# Altium schematic sheet OTL2_LED-Driver.SchDoc(OTL2_LED-Driver) (continued)
## Library data of the parts (continued)
R6 = RC0402FR-0722K1L: SMD Chip Resistor, 22.1 kOhm, ï¿½ 1%, 62.5 mW, 0402 [1005 Metric], Thick Film, General Purpose; Manufacturer: Yageo; Max Power Dissipation: 63mW; Power Rating: 62.5mW; Resistance: 22.1kR; Temperature Coefficient: 100ppm/°C; Voltage Rating: 50V; Case Code (Imperial): 0402; Case Code (Metric): 1005; Case/Package: 0402; Category: Chip SMD Resistors; Component Kind: Standard; ComponentLink1Description: https://datasheet.ciiva.com/2553/pyu-r-int-thick-7-2553200.pdf?src-supplier=Digikey; ComponentLink1URL: https://datasheet.ciiva.com/2553/pyu-r-int-thick-7-2553200.pdf?src-supplier=Digikey; ComponentLink2Description: https://datasheet.ciiva.com/2553/pyu-r-int-thick-7-2553201.pdf?src-supplier=Digikey; ComponentLink2URL: https://datasheet.ciiva.com/2553/pyu-r-int-thick-7-2553201.pdf?src-supplier=Digikey; ComponentLink3Description: https://datasheet.ciiva.com/2553/pyu-r-int-thick-7-2553202.pdf?src-supplier=Digikey; ComponentLink3URL: https://datasheet.ciiva.com/2553/pyu-r-int-thick-7-2553202.pdf?src-supplier=Digikey; ComponentLink4Description: https://datasheet.ciiva.com/7983/1018594-7983501.pdf?src-supplier=Element14; ComponentLink4URL: https://datasheet.ciiva.com/7983/1018594-7983501.pdf?src-supplier=Element14; ComponentLink5Description: https://datasheet.ciiva.com/15189/getdatasheetpartid-251817-15189388.pdf?src-supplier=Verical; ComponentLink5URL: https://datasheet.ciiva.com/15189/getdatasheetpartid-251817-15189388.pdf?src-supplier=Verical; ComponentLink6Description: LCSC; ComponentLink6URL: https://www.lcsc.com/product-detail/Chip-Resistor-Surface-Mount_YAGEO-RC0402FR-0722K1L_C163478.html; Construction: Rectangular; Depth: 0.5mm; Features: Moisture Resistant; Height: 0.016inch; Lead Free: Lead Free; Length: 0.039inch; Manufacturer Part Number: RC0402FR-0722K1L; Max Operating Temperature: 155°C; Military Standard: Not; Min Operating Temperature: -55°C; Number of Terminations: 2; Package Quantity: 1; Packaging: Tape and Reel; Pricing 1: 10000=0.0007, 50000=0.0007, 200000=0.0006, 500000=0.0006, 1250000=0.0006 (USD); Reach SVHC Compliant: No; Resistance Tolerance: 1%; Resistor Type: Thick Film; RoHS: Yes; RoHS Compliant: Yes; Series: RC; Stock 1: 30000; Supplier: LCSC; Supplier 1: Future Electronics; Supplier Part Number: C163478; Supplier Part Number 1: 7052236; Technology: THICK FILM; Termination: SMD/SMT; Tolerance: 1%; Voltage Rating (DC): 50V; Width: 0.02inch; Working Voltage: 50V; Library Name: josh-lib.SchLib
R7 R8 R35 = R0402 4K7 1% 63mW: Res Thick Film 0402 4.7K Ohm 1% 0.063W(1/16W) ±100ppm/C Pad SMD T/R; Manufacturer: Royal Ohm; Manufacturer Part Number: 0402WGF4701TCE; Resistance: 4.7kΩ; Temperature Coefficient: 100ppm/°C; Category: Chip SMD Resistors; Component Kind: Standard; ComponentLink1Description: https://datasheet.octopart.com:443/0402WGF4701TCE-Royal-Ohm-datasheet-165785611.pdf?src-supplier=TME; ComponentLink1URL: https://datasheet.octopart.com:443/0402WGF4701TCE-Royal-Ohm-datasheet-165785611.pdf?src-supplier=TME; ComponentLink2Description: https://datasheet.octopart.com:443/0402WGF4701TCE-Royal-Ohm-datasheet-157855877.pdf?src-supplier=TME; ComponentLink2URL: https://datasheet.octopart.com:443/0402WGF4701TCE-Royal-Ohm-datasheet-157855877.pdf?src-supplier=TME; ComponentLink3Description: https://datasheet.octopart.com:443/0402WGF4701TCE-Royal-Ohm-datasheet-62069544.pdf?src-supplier=TME; ComponentLink3URL: https://datasheet.octopart.com:443/0402WGF4701TCE-Royal-Ohm-datasheet-62069544.pdf?src-supplier=TME; ComponentLink4Description: https://datasheet.octopart.com:443/0402WGF4701TCE-Royal-Ohm-datasheet-17591902.pdf?src-supplier=TME; ComponentLink4URL: https://datasheet.octopart.com:443/0402WGF4701TCE-Royal-Ohm-datasheet-17591902.pdf?src-supplier=TME; ComponentLink5Description: LCSC; ComponentLink5URL: https://jlcpcb.com/partdetail/26643-0402WGF4701TCE/C25900; Pricing 1: 10000=0.004 (USD); RoHS: Non-Compliant; Supplier: LCSC; Supplier 1: Mouser; Supplier Part Number: C25900; Supplier Part Number 1: 303-0402WGF4701TCE; Library Name: josh-lib.SchLib
R9 R10 R17 R33 R36 = 0402WGF1002TCE: Res Thick Film 0402 10K Ohm 1% 0.063W(1/16W) ±100ppm/C Pad SMD T/R; Manufacturer: Uni-Royal; Resistance: 10kΩ; Temperature Coefficient: 100ppm/°C; Category: Chip SMD Resistors; Component Kind: Standard; ComponentLink1Description: https://datasheet.octopart.com:443/0402WGF1002TCE-Royal-Ohm-datasheet-165785611.pdf?src-supplier=TME; ComponentLink1URL: https://datasheet.octopart.com:443/0402WGF1002TCE-Royal-Ohm-datasheet-165785611.pdf?src-supplier=TME; ComponentLink2Description: https://datasheet.octopart.com:443/0402WGF1002TCE-Royal-Ohm-datasheet-157855877.pdf?src-supplier=TME; ComponentLink2URL: https://datasheet.octopart.com:443/0402WGF1002TCE-Royal-Ohm-datasheet-157855877.pdf?src-supplier=TME; ComponentLink3Description: https://datasheet.octopart.com:443/0402WGF1002TCE-Royal-Ohm-datasheet-62069544.pdf?src-supplier=TME; ComponentLink3URL: https://datasheet.octopart.com:443/0402WGF1002TCE-Royal-Ohm-datasheet-62069544.pdf?src-supplier=TME; ComponentLink4Description: https://datasheet.octopart.com:443/0402WGF1002TCE-Royal-Ohm-datasheet-17591902.pdf?src-supplier=TME; ComponentLink4URL: https://datasheet.octopart.com:443/0402WGF1002TCE-Royal-Ohm-datasheet-17591902.pdf?src-supplier=TME; ComponentLink5Description: LCSC; ComponentLink5URL: https://jlcpcb.com/partdetail/26487-0402WGF1002TCE/C25744; Manufacturer Part Number: 0402WGF1002TCE; Pricing 1: 10000=0.001 (USD); RoHS: Non-Compliant; Stock 1: 130000; Supplier: LCSC; Supplier 1: Mouser; Supplier Part Number: C25744; Supplier Part Number 1: 303-0402WGF1002TCE; Library Name: josh-lib.SchLib
R11 R12 R30 R38 R39 = RC0402FR-070RL: Res Thick Film 0402 0 Ohm Molded SMD SMD Paper T/R; Manufacturer: Yageo; Power Rating: 62.5mW; Resistance: 0mR; Temperature Coefficient: 200ppm/°C; Tolerance: 1%; Voltage Rating: 50V; Case Code (Imperial): 0402; Case Code (Metric): 1005; Case/Package: 0402; Category: Chip SMD Resistors; Component Kind: Standard; ComponentLink1Description: https://datasheet.ciiva.com/8896/pyu-rc0402-51-rohs-l-6-8896149.pdf?src-supplier=Avnet; ComponentLink1URL: https://datasheet.ciiva.com/8896/pyu-rc0402-51-rohs-l-6-8896149.pdf?src-supplier=Avnet; ComponentLink2Description: https://datasheet.ciiva.com/16790/pyu-rc0402-51-rohs-l-6-r-16790444.pdf?src-supplier=Future+Electronics; ComponentLink2URL: https://datasheet.ciiva.com/16790/pyu-rc0402-51-rohs-l-6-r-16790444.pdf?src-supplier=Future+Electronics; ComponentLink3Description: https://datasheet.ciiva.com/28419/pyu-rc0402-51-rohs-l-6-28419661.pdf?src-supplier=Avnet+Europe; ComponentLink3URL: https://datasheet.ciiva.com/28419/pyu-rc0402-51-rohs-l-6-28419661.pdf?src-supplier=Avnet+Europe; ComponentLink4Description: https://datasheet.ciiva.com/16869/pyu-rc0402-51-rohs-l-6-16869518.pdf?src-supplier=Avnet+Europe; ComponentLink4URL: https://datasheet.ciiva.com/16869/pyu-rc0402-51-rohs-l-6-16869518.pdf?src-supplier=Avnet+Europe; ComponentLink5Description: LCSC; ComponentLink5URL: https://www.lcsc.com/product-detail/Chip-Resistor-Surface-Mount_YAGEO-RC0402FR-070RL_C106231.html; Composition: Thick Film; Contact Plating: Tin,Matte; Height: 0.35mm; Lead Free: Lead Free; Length: 1mm; Manufacturer Part Number: RC0402FR-070RL; Max Operating Temperature: 155°C; Min Operating Temperature: -55°C; Mount: Surface Mount; Packaging: Tape and Reel; Pricing 1: 1=0.10000, 10=0.01300, 25=0.00920, 50=0.00720, 100=0.00530, 250=0.00400, 500=0.00320, 1000=0.00236, 5000=0.00169 (USD); Radiation Hardening: No; RoHS: Yes; Stock 1: 1551811; Supplier: LCSC; Supplier 1: Digi-Key; Supplier Part Number: C106231; Supplier Part Number 1: 311-0.0LRCT-ND; Termination: SMD/SMT; Width: 0.5mm; Library Name: josh-lib.SchLib
R13 R14 = R0402 5K1 1% 63mW: Res Thick Film 0402 5.1K Ohm 1% 0.063W(1/16W) ±100ppm/C Pad SMD T/R; Manufacturer: Uni-Royal; Manufacturer Part Number: 0402WGF5101TCE; Resistance: 5.1kΩ; Temperature Coefficient: 100ppm/°C; Category: Chip SMD Resistors; Component Kind: Standard; ComponentLink1Description: https://datasheet.octopart.com:443/0402WGF5101TCE-Royal-Ohm-datasheet-165785611.pdf?src-supplier=TME; ComponentLink1URL: https://datasheet.octopart.com:443/0402WGF5101TCE-Royal-Ohm-datasheet-165785611.pdf?src-supplier=TME; ComponentLink2Description: https://datasheet.octopart.com:443/0402WGF5101TCE-Royal-Ohm-datasheet-157855877.pdf?src-supplier=TME; ComponentLink2URL: https://datasheet.octopart.com:443/0402WGF5101TCE-Royal-Ohm-datasheet-157855877.pdf?src-supplier=TME; ComponentLink3Description: https://datasheet.octopart.com:443/0402WGF5101TCE-Royal-Ohm-datasheet-62069544.pdf?src-supplier=TME; ComponentLink3URL: https://datasheet.octopart.com:443/0402WGF5101TCE-Royal-Ohm-datasheet-62069544.pdf?src-supplier=TME; ComponentLink4Description: https://datasheet.octopart.com:443/0402WGF5101TCE-Royal-Ohm-datasheet-17591902.pdf?src-supplier=TME; ComponentLink4URL: https://datasheet.octopart.com:443/0402WGF5101TCE-Royal-Ohm-datasheet-17591902.pdf?src-supplier=TME; ComponentLink5Description: https://datasheet.octopart.com:443/0402WGF5101TCE-Royal-Ohm-datasheet-181080982.pdf?src-supplier=Rapid+Electronics; ComponentLink5URL: https://datasheet.octopart.com:443/0402WGF5101TCE-Royal-Ohm-datasheet-181080982.pdf?src-supplier=Rapid+Electronics; ComponentLink6Description: LCSC; ComponentLink6URL: https://jlcpcb.com/partdetail/26648-0402WGF5101TCE/C25905; Pricing 1: 10000=0.004 (USD); Stock 1: 40000; Supplier: LCSC; Supplier 1: Mouser; Supplier Part Number: C25905; Supplier Part Number 1: 303-0402WGF5101TCE; Library Name: josh-lib.SchLib
R15 = R0402 1M 1% 63mW: Res Thick Film 0402 1M Ohm 1% 0.063W(1/16W) ±100ppm/C Pad SMD T/R; Manufacturer: Uni-Royal; Manufacturer Part Number: 0402WGF1004TCE; Resistance: 1MΩ; Temperature Coefficient: 100ppm/°C; Category: Chip SMD Resistors; Component Kind: Standard; ComponentLink1Description: https://datasheet.octopart.com:443/0402WGF1004TCE-Royal-Ohm-datasheet-165785611.pdf?src-supplier=TME; ComponentLink1URL: https://datasheet.octopart.com:443/0402WGF1004TCE-Royal-Ohm-datasheet-165785611.pdf?src-supplier=TME; ComponentLink2Description: https://datasheet.octopart.com:443/0402WGF1004TCE-Royal-Ohm-datasheet-157855877.pdf?src-supplier=TME; ComponentLink2URL: https://datasheet.octopart.com:443/0402WGF1004TCE-Royal-Ohm-datasheet-157855877.pdf?src-supplier=TME; ComponentLink3Description: https://datasheet.octopart.com:443/0402WGF1004TCE-Royal-Ohm-datasheet-62069544.pdf?src-supplier=TME; ComponentLink3URL: https://datasheet.octopart.com:443/0402WGF1004TCE-Royal-Ohm-datasheet-62069544.pdf?src-supplier=TME; ComponentLink4Description: https://datasheet.octopart.com:443/0402WGF1004TCE-Royal-Ohm-datasheet-17591902.pdf?src-supplier=TME; ComponentLink4URL: https://datasheet.octopart.com:443/0402WGF1004TCE-Royal-Ohm-datasheet-17591902.pdf?src-supplier=TME; ComponentLink5Description: LCSC; ComponentLink5URL: https://www.lcsc.com/product-detail/C26083.html?s_z=n_0402WGF1004TCE; Pricing 1: 10000=0.001 (USD); RoHS: Non-Compliant; Supplier: LCSC; Supplier 1: Mouser; Supplier Part Number: C26083; Supplier Part Number 1: 303-0402WGF1004TCE; Library Name: josh-lib.SchLib
R16 R23 R26 R27 = R0402 4R7 63mW 1%: SMD Chip Resistor 4.7 Ohm ½ 1% 62.5 Mw 0402 [1005 Metric] Thick Film General Purpose; Manufacturer: Yageo; Manufacturer Part Number: RC0402FR-074R7L; Max Power Dissipation: 63mW; Resistance: 4.7R; Temperature Coefficient: 200ppm/°C; Voltage Rating: 50V; Case Code (Imperial): 0402; Case Code (Metric): 1005; Case/Package: 0402; Category: Chip SMD Resistors; Component Kind: Standard; ComponentLink1Description: https://datasheet.ciiva.com/12577/pyu-rc0402-51-rohs-l-6-r-12577562.pdf?src-supplier=Mouser; ComponentLink1URL: https://datasheet.ciiva.com/12577/pyu-rc0402-51-rohs-l-6-r-12577562.pdf?src-supplier=Mouser; ComponentLink2Description: https://datasheet.ciiva.com/7850/1018594-7850030.pdf?src-supplier=Element14; ComponentLink2URL: https://datasheet.ciiva.com/7850/1018594-7850030.pdf?src-supplier=Element14; ComponentLink3Description: https://datasheet.ciiva.com/7850/1018594-7850031.pdf?src-supplier=Element14; ComponentLink3URL: https://datasheet.ciiva.com/7850/1018594-7850031.pdf?src-supplier=Element14; ComponentLink4Description: https://datasheet.ciiva.com/17419/pyu-rc0402-51-rohs-l-6-r-17419593.pdf?src-supplier=Future+Electronics; ComponentLink4URL: https://datasheet.ciiva.com/17419/pyu-rc0402-51-rohs-l-6-r-17419593.pdf?src-supplier=Future+Electronics; ComponentLink5Description: LCSC; ComponentLink5URL: https://lcsc.com/product-detail/Chip-Resistor-Surface-Mount_YAGEO-RC0402FR-074R7L_C137962.html; Features: Moisture Resistant; Height: 0.35mm; Lead Free: Lead Free; Length: 1mm; Max Operating Temperature: 155°C; Min Operating Temperature: -55°C; Number of Terminations: 2; Packaging: Tape and Reel; Power Rating: 63mW; Pricing 1: 10000=0.00154, 20000=0.00151, 40000=0.00147, 60000=0.00145, 80000=0.00142 (USD); Reach SVHC Compliant: Unknown; Resistance Tolerance: 1%; Resistor Type: Thick Film; RoHS: Yes; RoHS Compliant: Yes; Series: RC; Size Code: 0402; Stock 1: 30000; Supplier: LCSC; Supplier 1: Avnet; Supplier Part Number: C137962; Supplier Part Number 1: RC0402FR-074R7L; Termination: SMD/SMT; Tolerance: 1%; Voltage Rating (DC): 50V; Weight: 2.3E-05oz; Width: 0.5mm; Library Name: josh-lib.SchLib
R18-R22 = RC0402FR-07510RL: SMD Chip Resistor, 510 Ohm, ± 1%, 63 mW, 0402 [1005 Metric], Thick Film, General Purpose; Manufacturer: Yageo; Max Power Dissipation: 63mW; Resistance: 510R; Temperature Coefficient: 100ppm/°C; Voltage Rating: 50V; Case Code (Imperial): 0402; Case Code (Metric): 1005; Case/Package: 0402; Category: Chip SMD Resistors; Component Kind: Standard; ComponentLink1Description: https://datasheet.ciiva.com/2453/pyu-r-int-thick-7-2453398.pdf?src-supplier=Digi-Key; ComponentLink1URL: https://datasheet.ciiva.com/2453/pyu-r-int-thick-7-2453398.pdf?src-supplier=Digi-Key; ComponentLink2Description: https://datasheet.ciiva.com/2453/pyu-r-int-thick-7-2453399.pdf?src-supplier=Digi-Key; ComponentLink2URL: https://datasheet.ciiva.com/2453/pyu-r-int-thick-7-2453399.pdf?src-supplier=Digi-Key; ComponentLink3Description: https://datasheet.ciiva.com/2453/pyu-r-int-thick-7-2453397.pdf?src-supplier=Digi-Key; ComponentLink3URL: https://datasheet.ciiva.com/2453/pyu-r-int-thick-7-2453397.pdf?src-supplier=Digi-Key; ComponentLink4Description: https://datasheet.ciiva.com/17063/pyu-rc0402-51-rohs-l-6-r-17063430.pdf?src-supplier=Future+Electronics; ComponentLink4URL: https://datasheet.ciiva.com/17063/pyu-rc0402-51-rohs-l-6-r-17063430.pdf?src-supplier=Future+Electronics; ComponentLink5Description: LCSC; ComponentLink5URL: https://www.lcsc.com/product-detail/Chip-Resistor-Surface-Mount_YAGEO-RC0402FR-07510RL_C276273.html; Construction: Rectangular; Depth: 0.5mm; Features: Moisture Resistant; Height: 0.016inch; Lead Free: Lead Free; Length: 1mm; Manufacturer Part Number: RC0402FR-07510RL; Max Operating Temperature: 155°C; Military Standard: Not; Min Operating Temperature: -55°C; Number of Terminations: 2; Package Quantity: 1; Packaging: Tape and Reel; Power Rating: 63mW; Pricing 1: 10000=0.001, 50000=0.001, 300000=0.0009, 1000000=0.0009, 3000000=0.0008 (USD); Reach SVHC Compliant: Unknown; Resistance Tolerance: 1%; Resistor Type: Thick Film; RoHS: Yes; RoHS Compliant: Yes; Series: RC; Size Code: 0402; Stock 1: 130000; Supplier: LCSC; Supplier 1: Future Electronics; Supplier Part Number: C276273; Supplier Part Number 1: 6052880; Termination: SMD/SMT; Tolerance: 1%; Voltage Rating (DC): 50V; Weight: 2.3E-05oz; Width: 0.02inch; Library Name: josh-lib.SchLib
R24 = R0402 200K 1% 63mW Uni-Royal: Royal Ohm 0402WGF2003TCE 200K 1% 1/16W 0402 Thick Film Chip Resistor; Resistance: 200kΩ; Temperature Coefficient: 100ppm/°C; Category: Chip SMD Resistors; Component Kind: Standard; ComponentLink1Description: https://datasheet.octopart.com:443/0402WGF2003TCE-Royal-Ohm-datasheet-165785611.pdf?src-supplier=TME; ComponentLink1URL: https://datasheet.octopart.com:443/0402WGF2003TCE-Royal-Ohm-datasheet-165785611.pdf?src-supplier=TME; ComponentLink2Description: https://datasheet.octopart.com:443/0402WGF2003TCE-Royal-Ohm-datasheet-157855877.pdf?src-supplier=TME; ComponentLink2URL: https://datasheet.octopart.com:443/0402WGF2003TCE-Royal-Ohm-datasheet-157855877.pdf?src-supplier=TME; ComponentLink3Description: https://datasheet.octopart.com:443/0402WGF2003TCE-Royal-Ohm-datasheet-62069544.pdf?src-supplier=TME; ComponentLink3URL: https://datasheet.octopart.com:443/0402WGF2003TCE-Royal-Ohm-datasheet-62069544.pdf?src-supplier=TME; ComponentLink4Description: https://datasheet.octopart.com:443/0402WGF2003TCE-Royal-Ohm-datasheet-17591902.pdf?src-supplier=TME; ComponentLink4URL: https://datasheet.octopart.com:443/0402WGF2003TCE-Royal-Ohm-datasheet-17591902.pdf?src-supplier=TME; ComponentLink5Description: LCSC; ComponentLink5URL: https://jlcpcb.com/partdetail/26507-0402WGF2003TCE/C25764; Manufacturer: Royal Ohm; Manufacturer Part Number: 0402WGF2003TCE; Pricing 1: 10000=0.004 (USD); Stock 1: 370000; Supplier: LCSC; Supplier 1: Mouser; Supplier Part Number: C25764; Supplier Part Number 1: 303-0402WGF2003TCE; Library Name: josh-lib.SchLib
R25 = R1206 0R 250mW 1%: Thick Film Resistors - SMD RMC 1206 1/4W 1% T/R-5000; Manufacturer: Royal Ohm; Manufacturer Part Number: 1206W4F0000T5E; Resistance: 0Ω; Category: Uncategorized; Component Kind: Standard; ComponentLink1Description: https://datasheet.octopart.com:443/1206W4F0000T5E-Royal-Ohm-datasheet-165785611.pdf?src-supplier=TME; ComponentLink1URL: https://datasheet.octopart.com:443/1206W4F0000T5E-Royal-Ohm-datasheet-165785611.pdf?src-supplier=TME; ComponentLink2Description: https://datasheet.octopart.com:443/1206W4F0000T5E-Royal-Ohm-datasheet-62069544.pdf?src-supplier=TME; ComponentLink2URL: https://datasheet.octopart.com:443/1206W4F0000T5E-Royal-Ohm-datasheet-62069544.pdf?src-supplier=TME; ComponentLink3Description: LCSC; ComponentLink3URL: https://jlcpcb.com/partdetail/18576-1206W4F0000T5E/C17888; Pricing 1: 10000=0.003 (USD); Stock 1: 55000; Supplier: LCSC; Supplier 1: Mouser; Supplier Part Number: C17888; Supplier Part Number 1: 303-1206W4F0000T5E; Library Name: josh-lib.SchLib
R28 R29 = R0603 0R1 1% 200mW Thick-Film: Res Thick Film 0603 0.1 Ohm 1% 0.2W(1/5W) ±200ppm/C Pad SMD Automotive T/R; Manufacturer: Yageo Group; Manufacturer Part Number: PT0603FR-7W0R1L; Power Dissipation: 200mW; Resistance: 100mΩ; Temperature Coefficient: 200ppm/°C; Working Voltage: 141mV; Case/Package: 0603; Category: Chip SMD Resistors; China RoHS: Non-Compliant; Component Kind: Standard; ComponentLink1Description: https://datasheet.octopart.com:443/PT0603FR-7W0R1L-Yageo-datasheet-182189530.pdf?src-supplier=IHS; ComponentLink1URL: https://datasheet.octopart.com:443/PT0603FR-7W0R1L-Yageo-datasheet-182189530.pdf?src-supplier=IHS; ComponentLink2Description: https://datasheet.octopart.com:443/PT0603FR-7W0R1L-Yageo-datasheet-86814862.pdf?src-supplier=Upverter; ComponentLink2URL: https://datasheet.octopart.com:443/PT0603FR-7W0R1L-Yageo-datasheet-86814862.pdf?src-supplier=Upverter; ComponentLink3Description: https://datasheet.octopart.com:443/PT0603FR-7W0R1L-Yageo-datasheet-137700561.pdf?src-supplier=Farnell; ComponentLink3URL: https://datasheet.octopart.com:443/PT0603FR-7W0R1L-Yageo-datasheet-137700561.pdf?src-supplier=Farnell; ComponentLink4Description: https://datasheet.octopart.com:443/PT0603FR-7W0R1L-Yageo-Group-datasheet-178484659.pdf?src-supplier=Future+Electronics; ComponentLink4URL: https://datasheet.octopart.com:443/PT0603FR-7W0R1L-Yageo-Group-datasheet-178484659.pdf?src-supplier=Future+Electronics; ComponentLink5Description: https://datasheet.octopart.com:443/PT0603FR-7W0R1L-Yageo-datasheet-67811955.pdf?src-supplier=Future+Electronics; ComponentLink5URL: https://datasheet.octopart.com:443/PT0603FR-7W0R1L-Yageo-datasheet-67811955.pdf?src-supplier=Future+Electronics; ComponentLink6Description: https://datasheet.octopart.com:443/PT0603FR-7W0R1L-Yageo-datasheet-60851516.pdf?src-supplier=Future+Electronics; ComponentLink6URL: https://datasheet.octopart.com:443/PT0603FR-7W0R1L-Yageo-datasheet-60851516.pdf?src-supplier=Future+Electronics; ComponentLink7Description: https://datasheet.octopart.com:443/PT0603FR-7W0R1L-Yageo-datasheet-38202983.pdf?src-supplier=Future+Electronics; ComponentLink7URL: https://datasheet.octopart.com:443/PT0603FR-7W0R1L-Yageo-datasheet-38202983.pdf?src-supplier=Future+Electronics; ComponentLink8Description: https://datasheet.octopart.com:443/PT0603FR-7W0R1L-Yageo-datasheet-25356012.pdf?src-supplier=Future+Electronics; ComponentLink8URL: https://datasheet.octopart.com:443/PT0603FR-7W0R1L-Yageo-datasheet-25356012.pdf?src-supplier=Future+Electronics; ComponentLink9Description: http://sigma.octopart.com:80/conflict_mineral_statement/Yageo-company-3.pdf?src-supplier=Compliance+Statements; ComponentLink9URL: http://sigma.octopart.com:80/conflict_mineral_statement/Yageo-company-3.pdf?src-supplier=Compliance+Statements; ComponentLink10Description: http://sigma.octopart.com:80/rohs_statement/Yageo-company-2.pdf?src-supplier=Compliance+Statements; ComponentLink10URL: http://sigma.octopart.com:80/rohs_statement/Yageo-company-2.pdf?src-supplier=Compliance+Statements; ComponentLink11Description: LCSC; ComponentLink11URL: https://www.lcsc.com/product-detail/C858641.html?s_z=n_PT0603FR-7W0R1L; Country of Origin: Mainland China, Taiwan; Export Control Classification Number (ECCN) Code: EAR99; Harmonized Tariff Schedule (HTS) Code: 8533.21.00.30; Height: 450µm; Introduction Date: 2012-04-10; Lead Free: Lead Free; Lifecycle Status: Production; Max Operating Temperature: 155°C; Min Operating Temperature: -55°C; Mount: Surface Mount; Number of Terminals: 2; Packaging: Tape & Reel; Pricing 1: 5000=0.04286, 10000=0.0417, 20000=0.04065, 40000=0.0399, 80000=0.03897 (USD); REACH SVHC: Yes; RoHS: Compliant; Schedule B: 8533210045, 8533210045|8533210045|8533210045|8533210045|8533210045; Stock 1: 5000; Supplier: LCSC; Supplier 1: Avnet; Supplier Part Number: C858641; Supplier Part Number 1: PT0603FR-7W0R1L; Tolerance: 1%; Width: 800µm; Library Name: josh-lib.SchLib
R31 = R0402 49K9 1% 63mW: Thick Film Resistors - SMD RMC 0402 1/16W 1% T/R-10000; Manufacturer: Royal Ohm; Manufacturer Part Number: 0402WGF4992TCE; Resistance: 49.9kΩ; Temperature Coefficient: 100ppm/°C; Category: Uncategorized; Component Kind: Standard; ComponentLink1Description: https://datasheet.octopart.com:443/0402WGF4992TCE-Royal-Ohm-datasheet-165785611.pdf?src-supplier=TME; ComponentLink1URL: https://datasheet.octopart.com:443/0402WGF4992TCE-Royal-Ohm-datasheet-165785611.pdf?src-supplier=TME; ComponentLink2Description: https://datasheet.octopart.com:443/0402WGF4992TCE-Royal-Ohm-datasheet-157855877.pdf?src-supplier=TME; ComponentLink2URL: https://datasheet.octopart.com:443/0402WGF4992TCE-Royal-Ohm-datasheet-157855877.pdf?src-supplier=TME; ComponentLink3Description: https://datasheet.octopart.com:443/0402WGF4992TCE-Royal-Ohm-datasheet-62069544.pdf?src-supplier=TME; ComponentLink3URL: https://datasheet.octopart.com:443/0402WGF4992TCE-Royal-Ohm-datasheet-62069544.pdf?src-supplier=TME; ComponentLink4Description: LCSC; ComponentLink4URL: https://jlcpcb.com/partdetail/26640-0402WGF4992TCE/C25897; Pricing 1: 10000=0.004 (USD); Stock 1: 440000; Supplier: LCSC; Supplier 1: Mouser; Supplier Part Number: C25897; Supplier Part Number 1: 303-0402WGF4992TCE; Library Name: josh-lib.SchLib
R32 = R0402 3K24 1% 63mW: Thick Film Resistors - SMD RMC 0402 1/16W 1% T/R-10000; Manufacturer: Royal Ohm; Manufacturer Part Number: 0402WGF3241TCE; Resistance: 3.24kΩ; Category: Uncategorized; Component Kind: Standard; ComponentLink1Description: https://datasheet.octopart.com:443/0402WGF3241TCE-Royal-Ohm-datasheet-165785611.pdf?src-supplier=TME; ComponentLink1URL: https://datasheet.octopart.com:443/0402WGF3241TCE-Royal-Ohm-datasheet-165785611.pdf?src-supplier=TME; ComponentLink2Description: https://datasheet.octopart.com:443/0402WGF3241TCE-Royal-Ohm-datasheet-157855877.pdf?src-supplier=TME; ComponentLink2URL: https://datasheet.octopart.com:443/0402WGF3241TCE-Royal-Ohm-datasheet-157855877.pdf?src-supplier=TME; ComponentLink3Description: LCSC; ComponentLink3URL: https://jlcpcb.com/partdetail/12011-0402WGF3241TCE/C11457; Pricing 1: 10000=0.004 (USD); Supplier: LCSC; Supplier 1: Mouser; Supplier Part Number: C11457; Supplier Part Number 1: 303-0402WGF3241TCE; Library Name: josh-lib.SchLib
R34 = R0603 82K 1% 100mW JLC_1: -55℃~+155℃ 100mW 75V 82kΩ Thick Film Resistor ±1% ±100ppm/℃ 0603 Chip Resistor - Surface Mount ROHS; Manufacturer: Royal Ohm; Manufacturer Part Number: 0603WAF8202T5E; Category: Uncategorized; Component Kind: Standard; ComponentLink1Description: https://datasheet.octopart.com:443/0603WAF8202T5E-Royal-Ohm-datasheet-165785611.pdf?src-supplier=TME; ComponentLink1URL: https://datasheet.octopart.com:443/0603WAF8202T5E-Royal-Ohm-datasheet-165785611.pdf?src-supplier=TME; ComponentLink2Description: https://datasheet.octopart.com:443/0603WAF8202T5E-Royal-Ohm-datasheet-157855877.pdf?src-supplier=TME; ComponentLink2URL: https://datasheet.octopart.com:443/0603WAF8202T5E-Royal-Ohm-datasheet-157855877.pdf?src-supplier=TME; ComponentLink3Description: https://datasheet.octopart.com:443/0603WAF8202T5E-Royal-Ohm-datasheet-62069544.pdf?src-supplier=TME; ComponentLink3URL: https://datasheet.octopart.com:443/0603WAF8202T5E-Royal-Ohm-datasheet-62069544.pdf?src-supplier=TME; ComponentLink4Description: JLCPCB; ComponentLink4URL: https://jlcpcb.com/partdetail/23981-0603WAF8202T5E/C23254; Pricing 1: 10000=0.001 (USD); Resistance: 82kΩ; Stock 1: 155000; Supplier: LCSC; Supplier 1: Mouser; Supplier Part Number: C23254; Supplier Part Number 1: 303-0603WAF8202T5E; Library Name: josh-lib.SchLib
R37 = R0402 1K 1% 63mW JLC: 62.5mW Thick Film Resistors 50V ±100ppm/℃ ±1% 1kΩ 0402 Chip Resistor - Surface Mount ROHS; Manufacturer: Royal Ohm; Manufacturer Part Number: 0402WGF1001TCE; Temperature Coefficient: 100ppm/°C; Category: Chip SMD Resistors; Component Kind: Standard; ComponentLink1Description: https://datasheet.octopart.com:443/0402WGF1001TCE-Royal-Ohm-datasheet-165785611.pdf?src-supplier=TME; ComponentLink1URL: https://datasheet.octopart.com:443/0402WGF1001TCE-Royal-Ohm-datasheet-165785611.pdf?src-supplier=TME; ComponentLink2Description: https://datasheet.octopart.com:443/0402WGF1001TCE-Royal-Ohm-datasheet-157855877.pdf?src-supplier=TME; ComponentLink2URL: https://datasheet.octopart.com:443/0402WGF1001TCE-Royal-Ohm-datasheet-157855877.pdf?src-supplier=TME; ComponentLink3Description: https://datasheet.octopart.com:443/0402WGF1001TCE-Royal-Ohm-datasheet-62069544.pdf?src-supplier=TME; ComponentLink3URL: https://datasheet.octopart.com:443/0402WGF1001TCE-Royal-Ohm-datasheet-62069544.pdf?src-supplier=TME; ComponentLink4Description: https://datasheet.octopart.com:443/0402WGF1001TCE-Royal-Ohm-datasheet-17591902.pdf?src-supplier=TME; ComponentLink4URL: https://datasheet.octopart.com:443/0402WGF1001TCE-Royal-Ohm-datasheet-17591902.pdf?src-supplier=TME; ComponentLink5Description: JLCPCB; ComponentLink5URL: https://jlcpcb.com/partdetail/12256-0402WGF1001TCE/C11702; Pricing 1: 10000=0.001 (USD); Resistance: 1kΩ; RoHS: Non-Compliant; Stock 1: 200000; Supplier: LCSC; Supplier 1: Mouser; Supplier Part Number: C11702; Supplier Part Number 1: 303-0402WGF1001TCE; Library Name: josh-lib.SchLib
SW1-SW5 = CAP_TOUCH: Library Name: josh-lib.SchLib
SW8 SW9 = TS24CA: 1.8mm 3.5mm Rectangle button 50mA Horizontal attachment 4.55mm SPST 12V SMD Tactile Switches ROHS spst; Manufacturer: SHOU HAN; Component Kind: Standard; ComponentLink1Description: LCSC; ComponentLink1URL: https://www.lcsc.com/product-detail/Tactile-Switches_SHOU-HAN-TS24CA_C393942.html; Manufacturer Part Number: TS24CA; Supplier: LCSC; Supplier Part Number: C393942; Library Name: josh-lib.SchLib
U1 = ESP32-S3-MINI-1-N4R2: On-board PCB antenna SMD WiFi Modules ROHS; Manufacturer: Espressif Systems; Category: LDO; Component Kind: Standard; ComponentLink1Description: LCSC; ComponentLink1URL: https://www.lcsc.com/product-detail/WiFi-Modules_Espressif-Systems-ESP32-S3-MINI-1-N4R2_C3013941.html; Manufacturer Part Number: ESP32-S3-MINI-1-N4R2; Pricing 1: 1=3.01 (USD); RoHS: No; Stock 1: 387; Supplier: LCSC; Supplier 1: Digikey; Supplier Part Number: C3013941; Supplier Part Number 1: 1965-ESP32-S3-MINI-1-N4R2CT-ND; Library Name: josh-lib.SchLib
U2 U3 = TPS92511DDAR: 500mA 30W Anode Capable constant current buck LED Dvr 8-SO PowerPAD -40 to 125; Manufacturer: Texas Instruments; Dimming: Analog,PWM; Frequency: 500kHz; Max Output Voltage: 65V; Min Input Voltage: 4.5V; Min Output Voltage: 200mV; Number of Channels: 1; Quiescent Current: 2.8mA; Case/Package: HSOP; Category: LED Drivers; Component Kind: Standard; ComponentLink1Description: https://datasheet.ciiva.com/29602/tps92511-29602876.pdf?src-supplier=Digikey; ComponentLink1URL: https://datasheet.ciiva.com/29602/tps92511-29602876.pdf?src-supplier=Digikey; ComponentLink2Description: LCSC; ComponentLink2URL: https://www.lcsc.com/product-detail/LED-Drivers_Texas-Instruments-TPS92511DDAR_C114277.html; Lead Free: Lead Free; Manufacturer Part Number: TPS92511DDAR; Max Operating Temperature: 125°C; Max Output Current: 500mA; Max Supply Voltage: 65V; Min Operating Temperature: -40°C; Mount: Surface Mount; Number of Outputs: 1; Number of Pins: 8; Output Current per Channel: 500mA; Package Quantity: 2500; Packaging: Cut Tape; Pricing 1: 1=1.3, 10=1.165, 25=1.1056, 100=0.9083, 250=0.84904, 500=0.75032, 1000=0.59236 (USD); RoHS: Yes; RoHS Compliant: Yes; Series: -; Stock 1: 2967; Supplier: LCSC; Supplier 1: Digikey; Supplier Part Number: C114277; Supplier Part Number 1: 296-41001-1-ND; Switching Frequency: 500kHz; Library Name: josh-lib.SchLib
U4 = LMR51606XDBVR: SIMPLE SWITCHER® power converter with 4.5V-to-65V 0.6A synchronous buck and 25µA quiescent current 6-SOT-23 -40 to 150; Manufacturer: Texas Instruments; Case/Package: SOT-23; Category: Voltage Regulators - Switching; Component Kind: Standard; ComponentLink1Description: DigiKey; ComponentLink1URL: https://www.digikey.com/en/products/detail/texas-instruments/LMR51606XDBVR/22116882; ComponentLink2Description: LCSC; ComponentLink2URL: https://www.lcsc.com/product-detail/DC-DC-Converters_Texas-Instruments-LMR51606XDBVR_C21260865.html; Length: 2.9mm; Manufacturer Part Number: LMR51606XDBVR; Number of Pins: 6; Pricing 1: 1=0.96, 10=0.621, 50=0.621, 100=0.512, 1000=0.473, 10000=0.422 (USD); RoHS: No; Stock 1: 11828; Supplier: LCSC; Supplier 1: Mouser; Supplier Part Number: C21260865; Supplier Part Number 1: 595-LMR51606XDBVR; Thickness: 1.2mm; Width: 1.6mm; Library Name: josh-lib.SchLib
U5 = AMS1117-3.3_AMS: -40℃~+125℃ 0.003%Vout 1 1.1V@(800mA) 16V 1A 3.3V 5mA 72dB@(120Hz) Fixed Over Current Protection、Over Temperature Protection Positive SOT-223 Voltage Regulators - Linear, Low Drop Out (LDO) Regulators ROHS; Manufacturer: Advanced Monolithic Systems; Component Kind: Standard; ComponentLink1Description: LCSC; ComponentLink1URL: https://jlcpcb.com/partdetail/Advanced_MonolithicSystems-AMS1117_33/C6186; Manufacturer Part Number: AMS1117-3.3; Supplier: LCSC; Supplier Part Number: C6186; Library Name: josh-lib.SchLib
U6 = ALS-PT19-315C/L177/TR8: Light to Current Ambient Light Sensor Digital 3.3V/5V 2-Pin SMD T/R; Manufacturer: Everlight; Collector Emitter Breakdown Voltage: 5.5V; Dark Current: 100nA; Fall Time: 0.22ms; Lens Style: Flat; Number of Elements: 1; Polarity: NPN; Rise Time: 0.11ms; Wavelength: 630nm; Case/Package: SMD/SMT; Category: Sensors; Component Kind: Standard; ComponentLink1Description: https://datasheet.ciiva.com/12092/als-pt19-315c-l177-tr-datasheet-34741-12092024.pdf?src-supplier=Mouser; ComponentLink1URL: https://datasheet.ciiva.com/12092/als-pt19-315c-l177-tr-datasheet-34741-12092024.pdf?src-supplier=Mouser; ComponentLink2Description: https://datasheet.ciiva.com/4270/alspt19315cl177-tr8-1810-4270796.pdf?src-supplier=Arrow+Electronics; ComponentLink2URL: https://datasheet.ciiva.com/4270/alspt19315cl177-tr8-1810-4270796.pdf?src-supplier=Arrow+Electronics; ComponentLink3Description: LCSC; ComponentLink3URL: https://www.lcsc.com/product-detail/Ambient-Light-Sensors_Everlight-Elec-ALS-PT19-315C-L177-TR8_C146233.html; Manufacturer Part Number: ALS-PT19-315C/L177/TR8; Max Breakdown Voltage: 5.5V; Max Operating Temperature: 85°C; MFG: Everlight; Min Operating Temperature: -40°C; Mount: Surface Mount; MPN: https://www.lcsc.com/product-detail/Ambient-Light-Sensors_Everlight-Elec-ALS-PT19-315C-L177-TR8_C146233.html; Number of Pins: 2; Package Quantity: 1; Packaging: Tape and Reel; Pricing 1: 1=0.37, 5=0.314, 10=0.292, 25=0.2672, 50=0.2508, 100=0.2362, 500=0.20796, 1000=0.19788 (USD); Radiation Hardening: No; RoHS: Yes; RoHS Compliant: Yes; Series: -; Stock 1: 58753; Supplier 1: Digikey; Supplier Part Number 1: 1080-1244-1-ND; Library Name: josh-lib.SchLib
U7 = 74LVC1T45W6-7: 74LVC Series 5.5 V 3-State SMT Single Bit Dual Supply Transceiver - SOT-26; Manufacturer: Diodes; Channel Type: Bidirectional; High Level Output Current: -32mA; Logic Function: Translator; Low Level Output Current: 32mA; Max Supply Voltage: 5.5V; Min Supply Voltage: 1.65V; Number of Channels: 1; Operating Supply Current: 4uA; Quiescent Current: 3uA; Case/Package: SOT-23-6; Category: Voltage Level Shifters; Component Kind: Standard; ComponentLink1Description: http://www.mouser.com/ds/2/115/74LVC1T45-318124.pdf?src-supplier=Mouser; ComponentLink1URL: http://www.mouser.com/ds/2/115/74LVC1T45-318124.pdf?src-supplier=Mouser; ComponentLink2Description: https://www.diodes.com/assets/Datasheets/74LVC1T45.pdf?src-supplier=Digi-Key; ComponentLink2URL: https://www.diodes.com/assets/Datasheets/74LVC1T45.pdf?src-supplier=Digi-Key; ComponentLink3Description: http://www.diodes.com/datasheets/74LVC1T45.pdf?src-supplier=Avnet; ComponentLink3URL: http://www.diodes.com/datasheets/74LVC1T45.pdf?src-supplier=Avnet; ComponentLink4Description: https://datasheet.octopart.com/74LVC1T45W6-7-Diodes-Inc.-datasheet-160640065.pdf?src-supplier=TME; ComponentLink4URL: https://datasheet.octopart.com/74LVC1T45W6-7-Diodes-Inc.-datasheet-160640065.pdf?src-supplier=TME; ComponentLink5Description: LCSC; ComponentLink5URL: https://www.lcsc.com/product-detail/Translators-Level-Shifters_Diodes-Incorporated-74LVC1T45W6-7_C168856.html; Contact Plating: Tin,Matte; Manufacturer Part Number: 74LVC1T45W6-7; Max Operating Temperature: 125°C; Min Operating Temperature: -40°C; Mount: Surface Mount; Number of Circuits: 1; Output Type: 3-STATE; Package Quantity: 3000; Packaging: Tape and Reel; Pricing 1: 3000=0.0479, 9000=0.0461, 15000=0.0453, 60000=0.0432, 90000=0.0419 (USD); RoHS: Yes; RoHS Compliant: Yes; Series: 74LVC; Stock 1: 219000; Supplier: LCSC; Supplier 1: Future Electronics; Supplier Part Number: C168856; Supplier Part Number 1: 4080314; Library Name: josh-lib.SchLib
U8 = WS2812B-4020: SMD,2x4mm RGB LEDs(Built-in IC) ROHS; Manufacturer: Worldsemi; Component Kind: Standard; ComponentLink1Description: LCSC; ComponentLink1URL: https://www.lcsc.com/product-detail/RGB-LEDs-Built-in-IC_Worldsemi-WS2812B-4020_C965557.html?s_z=n_ws2812; Manufacturer Part Number: WS2812B-4020; Supplier: LCSC; Supplier Part Number: C965557; Library Name: josh-lib.SchLib
